# Altium schematic sheet sheet4_power.SchDoc
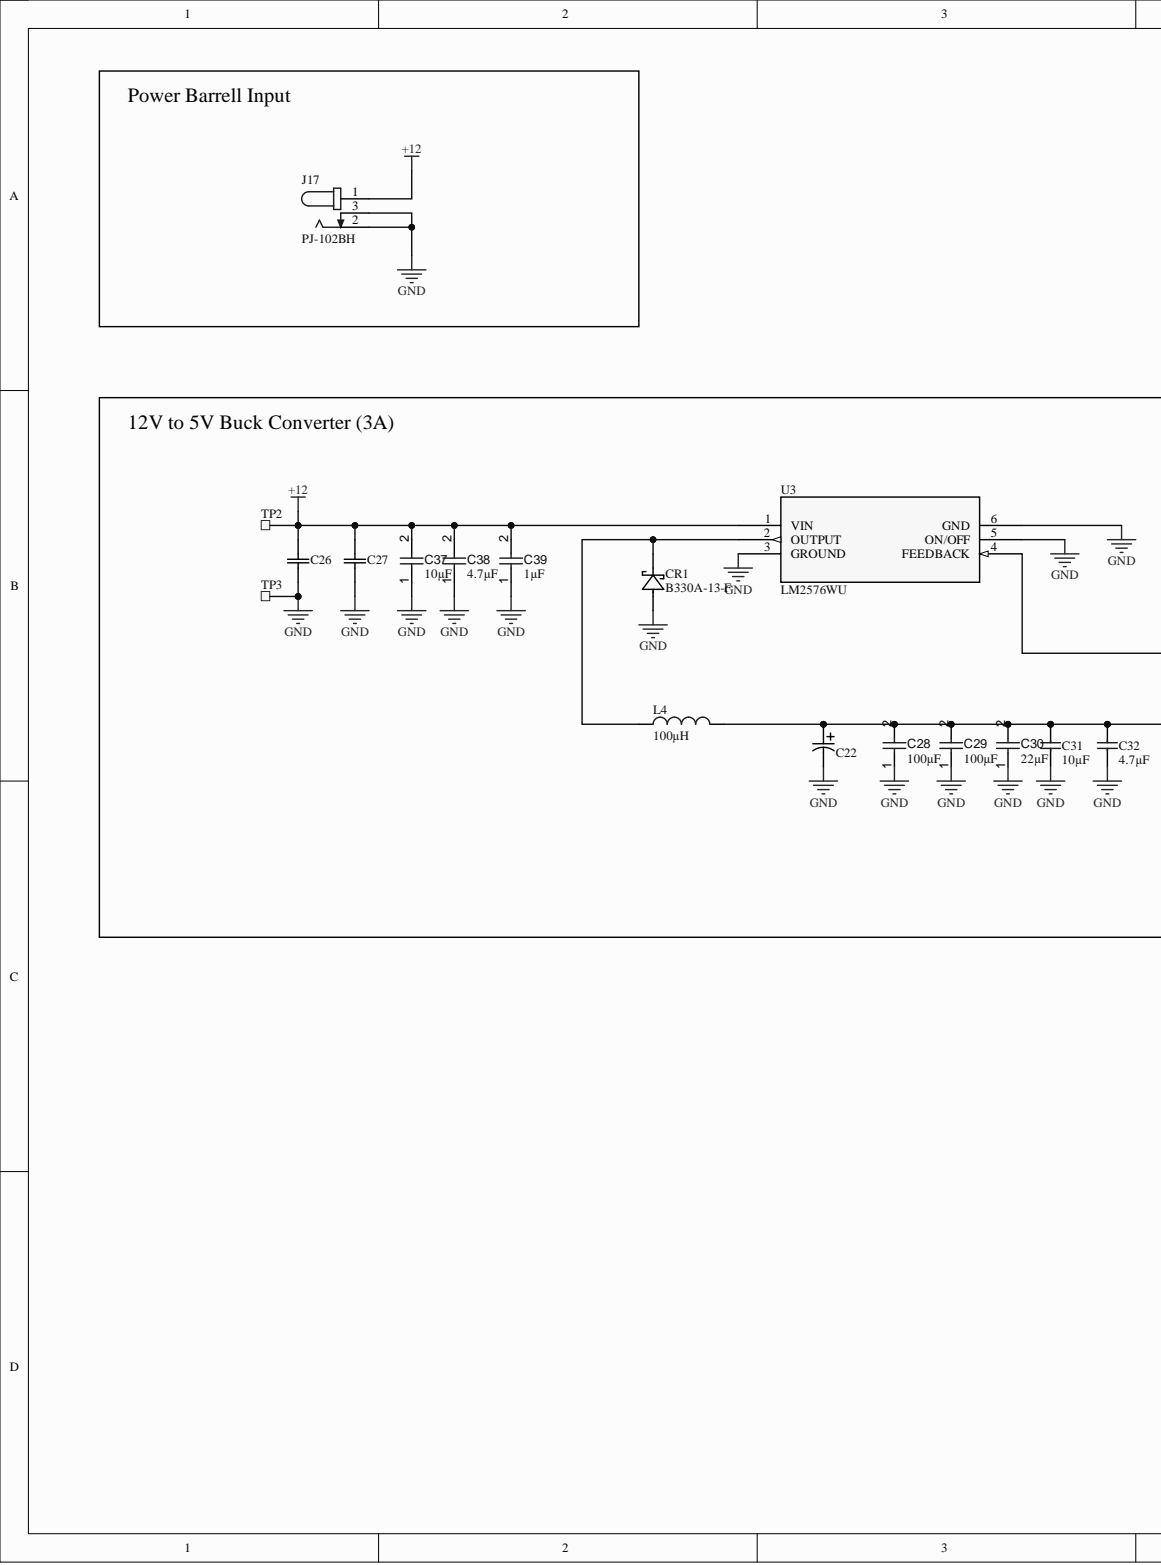
[diagram p0: sheet4_power.SchDoc, left]
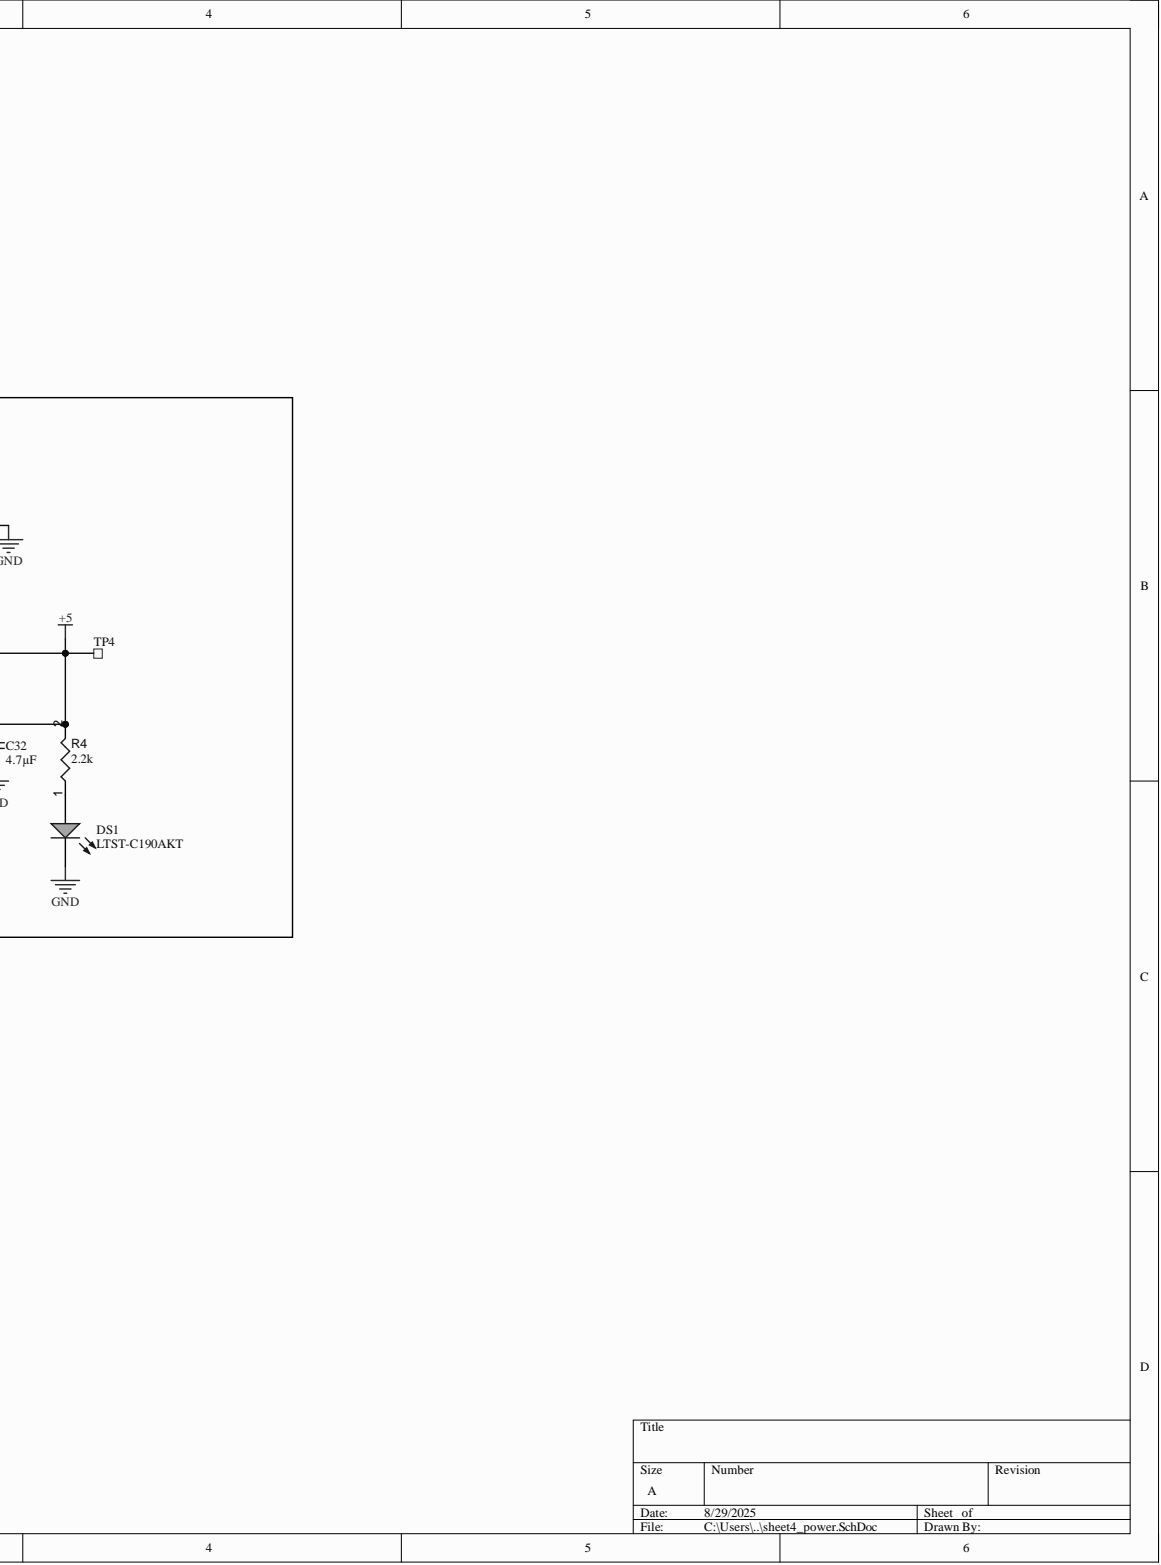
[diagram p1: sheet4_power.SchDoc, right]

## Parts
C22  UCD0J102MNL1GS  [PCAP_8x10-ELECT_NCA]  (value not drawn)
C26  C1210C107M4PACTU  [CAPC350280_280N_KEM]  (value not drawn)
C27  C1210C107M4PACTU  [CAPC350280_280N_KEM]  (value not drawn)
C28  value 100µF  [CAPC0805(2012)145_L]  (hidden comment: Capacitor 100µF +/-20% 6.3V 0805)
C29  value 100µF  [CAPC0805(2012)145_L]  (hidden comment: Capacitor 100µF +/-20% 6.3V 0805)
C30  value 22µF  [CAPC0805(2012)145_L]  (hidden comment: Capacitor 22µF +/-40% 16V 0805)
C31  10µF  [CAPC1608X06L]
C32  4.7µF  [CAPC1608X06L]
C37  value 10µF  [CAPC3216X180X50NL20T25]  (hidden comment: Capacitor 10 uF +/- 10% 16 V 1206)
C38  value 4.7µF  [CAPC0805(2012)145_L]  (hidden comment: Capacitor 4.7µF +/-40% 25V 0805)
C39  value 1µF  [CAPC3216X180X20ML20]  (hidden comment: Capacitor 1 uF +/- 10% 25 V 1206)
CR1  B330A-13-F  [SDO_SMA_DIO]
DS1  LTST-C190AKT  [LTST-C190AKT-2_V]
J17  PJ-102BH  [CUI_PJ-102BH]
L4  value 100µH  [MWSA1206S-101MT-Footprint-1]  (hidden comment: MWSA1206S-101MT)
R4  value 2.2k  [RESC0402(1005)_L]  (hidden comment: Resistor 2K2  +/-5 % 0402 63 mW)
TP2  Ø1.0mm,SMD  [TP_SMD_D1.0mm]  (value not drawn)  (no BOM)
TP3  Ø1.0mm,SMD  [TP_SMD_D1.0mm]  (value not drawn)  (no BOM)
TP4  Ø1.0mm,SMD  [TP_SMD_D1.0mm]  (value not drawn)  (no BOM)
U3  LM2576WU  [TO-263-5_U_MCH]

## Nets
+5: C22.1 C28.2 C29.2 C30.2 C31.2 C32.2 L4.2 R4.2 TP4.1 U3.4(FEEDBACK)
+12: C26.2 C27.2 C37.2 C38.2 C39.2 J17.1 TP2.1 U3.1(VIN)
GND: C22.2 C26.1 C27.1 C28.1 C29.1 C30.1 C31.1 C32.1 C37.1 C38.1 C39.1 CR1.2 DS1.2 J17.2 J17.3 TP3.1 U3.3(GROUND) U3.5(ON/OFF) U3.6(GND)
NetCR1_1: CR1.1 L4.1 U3.2(OUTPUT)
NetDS1_1: DS1.1 R4.1

## Library data of the parts
C22 = CMP-001-00083-1: No Description Available; Mfr_Name: Nichicon; Component Kind: Standard; Design Item ID: UCD0J102MNL1GS; Source: UCD0J102MNL1GS.SchLib; Library Name: Greenhouse Lysimeter Project
C26 C27 = CMP-001-00126-1: SMD Comm X5R, Ceramic, 100 uF, 20%, 16 VDC, X5R, SMD, MLCC, Temperature Stable, Class II, 1210; Manufacturer_Name: Kemet; Component Kind: Standard; Datasheet: https://content.kemet.com/datasheets/KEM_C1006_X5R_SMD.pdf; Design Item ID: C1210C107M4PACTU; Manufacturer_Part_Number: C1210C107M4PACTU; Source: C1210C107M4PACTU.SchLib; Copyright: Copyright (C) 2025 Ultra Librarian. All rights reserved.; Library Name: Greenhouse Lysimeter Project
C28 C29 = CMP-001-00054-4: Chip Capacitor, 100µF +/-20%, 6.3V, 0805, Thickness 1.45 mm; Tolerance: 10%; Case/Package: 0805; Component Kind: Standard; Max Operating Temperature: 85°C; Min Operating Temperature: -55°C; Mounting Technology: SMT; Pins: 2; Voltage Rating: 6.3V; Library Name: Greenhouse Lysimeter Project
C30 = CMP-001-00081-4: Chip Capacitor, 22µF +/-40%, 16V, 0805, Thickness 1.45 mm; Tolerance: 5%; Case/Package: 0805; Component Kind: Standard; Max Operating Temperature: 85°C; Min Operating Temperature: -55°C; Mounting Technology: SMT; Pins: 2; Voltage Rating: 16V; Library Name: Greenhouse Lysimeter Project
C31 = C0603-10µ: Capacitor, X5R, ±20%; Manufacturer: N.A.; Part number IPS: 00000007785; Artikkelnr Noca: 20039234; Note: 6.3V; Pad Count: 2; Component Class: Capacitors; Component Kind: Standard; ComponentLink1Description: Datasheet; ComponentLink1URL: N.A.; ComponentLink2Description: Supplier link; ComponentLink2URL: N.A.; Footprint Doc: 0603; HelpURL: N.A.; LatestRevisionDate: 2011-01-17; Library Name: pca10040.IntLib; Manufacturer Part Number: N.A.; Part Number: C0603-10µ; Part number Norautron: N.A.; PartId: 100200275; Published: 2011-01-17; Supplier 1: N.A.; Supplier 2: N.A.; Supplier Part Number 1: N.A.; Supplier Part Number 2: N.A.; Value: 10µF; Author: rsk
C32 = C0603-4µ7-10V-X7S: Capacitor, X7S, ±10%, 10V; Manufacturer: N.A.; Part number IPS: 00000001779; Artikkelnr Noca: 20030013; Pad Count: 2; Component Class: Capacitors; Component Kind: Standard; ComponentLink1Description: Datasheet; ComponentLink1URL: N.A.; ComponentLink2Description: Supplier link; ComponentLink2URL: N.A.; Footprint Doc: 0603; HelpURL: N.A.; LatestRevisionDate: 2016-08-15; Library Name: pca10040.IntLib; Manufacturer Part Number: N.A.; Note: 10V; Part Number: C0603-4µ7-10V-X7S; Part number Norautron: N.A.; PartId: 100200351; Published: 2016-08-15; Supplier 1: Digi-Key; Supplier 2: N.A.; Supplier Part Number 1: 445-14258-1-ND; Supplier Part Number 2: N.A.; Value: 4.7µF; Author: rsk
C37 = CMP-001-00016-6: Chip Capacitor, 10 uF, +/- 10%, 16 V, 1206 (3216 Metric); Capacitor Type: Ceramic; Voltage Rating: 16V; Case/Package: 1206; Component Kind: Standard; Max Operating Temperature: 125°C; Min Operating Temperature: -55°C; Mounting Technology: SM; Pins: 2; RoHS Compliant: True; Tolerance: 10%; Library Name: Greenhouse Lysimeter Project
C38 = CMP-001-00080-4: Chip Capacitor, 4.7µF +/-40%, 25V, 0805, Thickness 1.45 mm; Tolerance: 20%; Case/Package: 0805; Component Kind: Standard; Max Operating Temperature: 85°C; Min Operating Temperature: -55°C; Mounting Technology: SMT; Pins: 2; Voltage Rating: 25V; Library Name: Greenhouse Lysimeter Project
C39 = CMP-001-00006-6: Chip Capacitor, 1 uF, +/- 10%, 25 V, 1206 (3216 Metric); Capacitor Type: Ceramic; Voltage Rating: 25V; Case/Package: 1206; Component Kind: Standard; Max Operating Temperature: 125°C; Min Operating Temperature: -55°C; Mounting Technology: SM; Pins: 2; RoHS Compliant: True; Tolerance: 10%; Library Name: Greenhouse Lysimeter Project
CR1 = CMP-045-000000-1: No Description Available; Mfr_Name: Diodes Inc; Component Kind: Standard; Design Item ID: B330A-13-F; Source: B330A-13-F.SchLib; Library Name: Greenhouse Lysimeter Project
DS1 = CMP-007-00021-2: LED Uni-Color Orange, 100 mW, 30 mA, -55 to 85 degC, 2-Pin SMD, RoHS, Tape and Reel; Manufacturer: Lite-On; Forward Current: 20mA; Forward Voltage: 2.1V; Lens Style: Clear; Luminous Intensity: 2mcd; Viewing Angle: 130degrees; Case/Package: 0603; Colour: Orange; Component Kind: Standard; Datasheet URL: http://datasheet.octopart.com/LTST-C190AKT-Lite-On-datasheet-11729267.pdf; Datasheet Version: Jan-03; Illumination Colour: Orange; Manufacturer URL: http://www.us.liteon.com/; Max Operating Temperature: 85°C; Min Operating Temperature: -55°C; Mounting Technology: SM; Nominal Vf: 2.1V; Package Description: 2-Pin Surface Mount Device, Body 1.6 x 0.8 mm; Package Quantity: 3000; Package Reference: LTST-C190AKT-2; Packaging: TapeandReel; Pins: 2; RoHS Compliant: True; Library Name: Greenhouse Lysimeter Project
J17 = CMP-002-00071-2: 2.5 x 6.5 mm, 5.0 A, Horizontal, Through Hole, Tapered Pins, Dc Power Jack Connector; MF: Same Sky; Case/Package: None; Check_prices: https://www.snapeda.com/parts/PJ-102BH/Same+Sky/view-part/?ref=eda; Component Kind: Standard; Component Type: Standard; Design Item ID: PJ-102BH; MP: PJ-102BH; Pins: 3; SnapEDA_Link: https://www.snapeda.com/parts/PJ-102BH/Same+Sky/view-part/?ref=snap; Source: PJ-102BH.SchLib; Library Name: Greenhouse Lysimeter Project
L4 = CMP-006-00038-1: 3A 100uH ±20% 3.5A SMD,13.5x12.6mm Power Inductors ROHS; Component Kind: Standard; Max Current Rating: 3A; Pins: 2; Library Name: Greenhouse Lysimeter Project
R4 = CMP-009-00166-3: Chip Resistor, 2,2 kOhms, +/-5 %, 63 mW, -55 to 155 degC, 0402; Power: 63mW; Tolerance: 5%; Case/Package: 0402; Component Kind: Standard; Max Operating Temperature: 155°C; Min Operating Temperature: -55°C; Pins: 2; Library Name: Greenhouse Lysimeter Project
TP2-TP4 = TP_SMD_Ø1.0MM: 1.0mm circular SMD testpad; Manufacturer: Nordic Semiconductor; Artikkelnr Noca: N.A.; Pad Count: 1; Component Class: Connectors; ComponentLink1Description: Datasheet; ComponentLink1URL: N.A.; ComponentLink2Description: Supplier link; ComponentLink2URL: N.A.; Footprint Doc: TP_SMD_Ø1.0mm; HelpURL: N.A.; LatestRevisionDate: 2021-03-05; Library Name: pca10040.IntLib; Manufacturer Part Number: N.A.; Note: N.A.; Part Number: TP_SMD_Ø1.0MM; Part number IPS: N.A.; Part number Norautron: N.A.; PartId: 100500475; Published: 2013-06-11; Supplier 1: N.A.; Supplier 2: N.A.; Supplier Part Number 1: N.A.; Supplier Part Number 2: N.A.; Value: Ø1.0mm,SMD; Author: rsk
U3 = CMP-035-000000-1: No Description Available; Mfr_Name: Micrel / Microchip Technology; Component Kind: Standard; Design Item ID: LM2576WU; RefDes: RefDes; Source: LM2576WU.SchLib; Type: Type; Library Name: Greenhouse Lysimeter Project
